# Altium schematic sheet logika_sch_ideowy.SchDoc
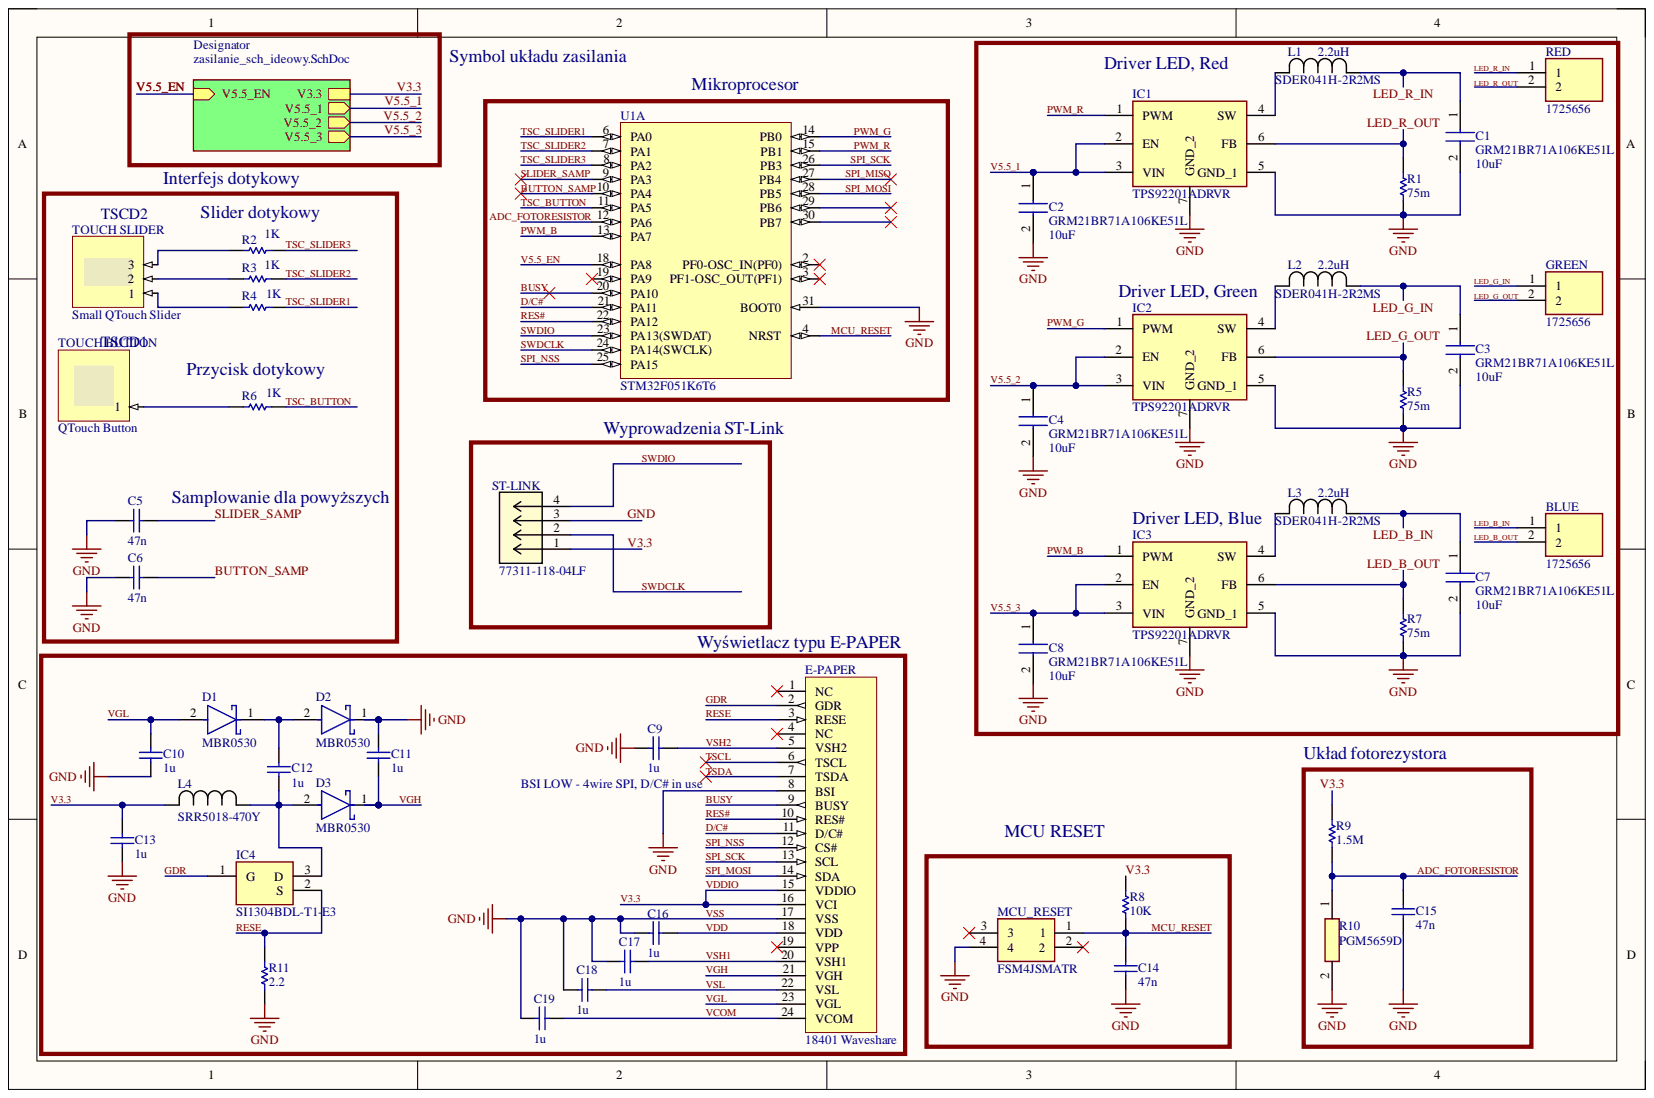
[diagram p0: logika_sch_ideowy.SchDoc]

## Parts
BLUE  1725656  [1725656]
C1  GRM21BR71A106KE51L  Capacitance: 10uF  [CAPC2012X145N]
C2  GRM21BR71A106KE51L  Capacitance: 10uF  [CAPC2012X145N]
C3  GRM21BR71A106KE51L  Capacitance: 10uF  [CAPC2012X145N]
C4  GRM21BR71A106KE51L  Capacitance: 10uF  [CAPC2012X145N]
C5  value 47n  [Capacitor_0805]  (hidden comment: Capacitor)
C6  value 47n  [Capacitor_0805]  (hidden comment: Capacitor)
C7  GRM21BR71A106KE51L  Capacitance: 10uF  [CAPC2012X145N]
C8  GRM21BR71A106KE51L  Capacitance: 10uF  [CAPC2012X145N]
C9  value 1u  [Capacitor_0805]  (hidden comment: Capacitor)
C10  value 1u  [Capacitor_0805]  (hidden comment: Capacitor)
C11  value 1u  [Capacitor_0805]  (hidden comment: Capacitor)
C12  value 1u  [Capacitor_0805]  (hidden comment: Capacitor)
C13  value 1u  [Capacitor_0805]  (hidden comment: Capacitor)
C14  value 47n  [Capacitor_0805]  (hidden comment: Capacitor)
C15  value 47n  [Capacitor_0805]  (hidden comment: Capacitor)
C16  value 1u  [Capacitor_0805]  (hidden comment: Capacitor)
C17  value 1u  [Capacitor_0805]  (hidden comment: Capacitor)
C18  value 1u  [Capacitor_0805]  (hidden comment: Capacitor)
C19  value 1u  [Capacitor_0805]  (hidden comment: Capacitor)
D1  MBR0530  [FP-425-04-MFG]
D2  MBR0530  [FP-425-04-MFG]
D3  MBR0530  [FP-425-04-MFG]
E-PAPER  18401 Waveshare  MPN: 18401  [18401 Waveshare]
GREEN  1725656  [1725656]
IC1  TPS92201ADRVR  [SON65P200X200X80-7N]
IC2  TPS92201ADRVR  [SON65P200X200X80-7N]
IC3  TPS92201ADRVR  [SON65P200X200X80-7N]
IC4  SI1304BDL-T1-E3  [SOT65P210X110-3N]
L1  SDER041H-2R2MS  value 2.2uH  [IND_SDER041H_CYN]
L2  SDER041H-2R2MS  value 2.2uH  [IND_SDER041H_CYN]
L3  SDER041H-2R2MS  value 2.2uH  [IND_SDER041H_CYN]
L4  SRR5018-470Y  [IND_BOURNS_SRR5018]
MCU_RESET  FSM4JSMATR  [FSM4JSMATR]
R1  value 75m  [Resistor_0805]  (hidden comment: Resistor)
R2  value 1K  [Resistor_0805]  (hidden comment: Resistor)
R3  value 1K  [Resistor_0805]  (hidden comment: Resistor)
R4  value 1K  [Resistor_0805]  (hidden comment: Resistor)
R5  value 75m  [Resistor_0805]  (hidden comment: Resistor)
R6  value 1K  [Resistor_0805]  (hidden comment: Resistor)
R7  value 75m  [Resistor_0805]  (hidden comment: Resistor)
R8  value 10K  [Resistor_0805]  (hidden comment: Resistor)
R9  value 1.5M  [Resistor_0805]  (hidden comment: Resistor)
R10  PGM5659D  [PGM5659D]
R11  value 2.2  [Resistor_0805]  (hidden comment: Resistor)
RED  1725656  [1725656]
ST-LINK  77311-118-04LF  [77311-118-04LF_AMP]
TOUCH_BUTTON  QTouch Button  (no BOM)
TOUCH_SLIDER  Small QTouch Slider  (no BOM)
U1  STM32F051K6T6  [STM-LQFP32_L]  (part A)

## Nets
ADC_FOTORESISTOR: C15.2 R9.1 R10.1 U1.12(PA6)
BUSY: E-PAPER.9(BUSY) U1.20(PA10)
BUTTON_SAMP: C6.2 U1.10(PA4)
D/C#: E-PAPER.11(D/C#) U1.21(PA11)
GDR: E-PAPER.2(GDR) IC4.1
GND: C1.2 C2.2 C3.2 C4.2 C5.1 C6.1 C7.2 C8.2 C9.1 C10.1 C11.2 C13.1 C14.1 C15.1 C16.1 C17.1 C18.1 C19.1 D2.1 E-PAPER.8(BSI) E-PAPER.17(VSS) IC1.5(GND_1) IC1.7(GND_2) IC2.5(GND_1) IC2.7(GND_2) IC3.5(GND_1) IC3.7(GND_2) MCU_RESET.4 R1.1 R5.1 R7.1 R10.2 R11.1 ST-LINK.3 U1.31(BOOT0)
LED_B_IN: BLUE.1 C7.1 L3.1
LED_B_OUT: BLUE.2 IC3.6(FB) R7.2
LED_G_IN: C3.1 GREEN.1 L2.1
LED_G_OUT: GREEN.2 IC2.6(FB) R5.2
LED_R_IN: C1.1 L1.1 RED.1
LED_R_OUT: IC1.6(FB) R1.2 RED.2
MCU_RESET: C14.2 MCU_RESET.1 R8.1 U1.4(NRST)
PWM_B: IC3.1(PWM) U1.13(PA7)
PWM_G: IC2.1(PWM) U1.14(PB0)
PWM_R: IC1.1(PWM) U1.15(PB1)
RES#: E-PAPER.10(RES#) U1.22(PA12)
RESE: E-PAPER.3(RESE) IC4.2 R11.2
SLIDER_SAMP: C5.2 U1.9(PA3)
SPI_MISO: U1.27(PB4)
SPI_MOSI: E-PAPER.14(SDA) U1.28(PB5)
SPI_NSS: E-PAPER.12(CS#) U1.25(PA15)
SPI_SCK: E-PAPER.13(SCL) U1.26(PB3)
SWDCLK: ST-LINK.2 U1.24(PA14(SWCLK))
SWDIO: ST-LINK.4 U1.23(PA13(SWDAT))
TSC_BUTTON: R6.2 U1.11(PA5)
TSC_SLIDER1: R4.2 U1.6(PA0)
TSC_SLIDER2: R3.2 U1.7(PA1)
TSC_SLIDER3: R2.2 U1.8(PA2)
TSCL: E-PAPER.6(TSCL)
TSDA: E-PAPER.7(TSDA)
V3.3: C13.2 E-PAPER.15(VDDIO) E-PAPER.16(VCI) L4.2 R8.2 R9.2 ST-LINK.1
V5.5_1: C2.1 IC1.2(EN) IC1.3(VIN)
V5.5_2: C4.1 IC2.2(EN) IC2.3(VIN)
V5.5_3: C8.1 IC3.2(EN) IC3.3(VIN)
V5.5_EN: U1.18(PA8)
VCOM: C19.2 E-PAPER.24(VCOM)
VDD: C16.2 E-PAPER.18(VDD)
VGH: C11.1 D3.1 E-PAPER.21(VGH)
VGL: C10.2 D1.2 E-PAPER.23(VGL)
VSH1: C17.2 E-PAPER.20(VSH1)
VSH2: C9.2 E-PAPER.5(VSH2)
VSL: C18.2 E-PAPER.22(VSL)
NetC12_1: C12.1 D3.2 IC4.3 L4.1
NetC12_2: C12.2 D1.1 D2.2
NetIC1_4: IC1.4(SW) L1.2
NetIC2_4: IC2.4(SW) L2.2
NetIC3_4: IC3.4(SW) L3.2
NetR2_1: R2.1 TOUCH_SLIDER.3
NetR3_1: R3.1 TOUCH_SLIDER.2
NetR4_1: R4.1 TOUCH_SLIDER.1
NetR6_1: R6.1 TOUCH_BUTTON.1

Not connected: E-PAPER 1(NC) 4(NC) 19(VPP); MCU_RESET 2 3; U1 2(PF0-OSC_IN(PF0)) 3(PF1-OSC_OUT(PF1)) 19(PA9) 29(PB6) 30(PB7)

## Library data of the parts
BLUE GREEN RED = 1725656: 2-pin screw terminal block Connector; Manufacturer_Name: Phoenix Contact; Arrow Part Number: 1725656; Arrow Price/Stock: https://www.arrow.com/en/products/1725656/phoenix-contact?utm_currency=USD&region=nac; Component Kind: Standard; Datasheet Link: https://www.phoenixcontact.com/online/portal/us/?uri=pxc-oc-itemdetail:pid=1725656&library=usen&pcck=P-11-01-05&tab=1&selectedCategory=ALL; Height: 8.65mm; Library Name: Schlib1.SchLib; Manufacturer_Part_Number: 1725656; Mouser Part Number: 651-1725656; Mouser Price/Stock: https://www.mouser.co.uk/ProductDetail/Phoenix-Contact/1725656?qs=Ul7CXFMnlWWQeccayYbRmw%3D%3D
C1-C4 C7 C8 = GRM21BR71A106KE51L: Capacitor, LED DRIVER; Manufacturer_Name: Murata Electronics; Arrow Part Number: GRM21BR71A106KE51L; Arrow Price/Stock: https://www.arrow.com/en/products/grm21br71a106ke51l/murata-manufacturing; Component Kind: Standard; Datasheet Link: https://search.murata.co.jp/Ceramy/image/img/A01X/G101/ENG/GRM21BR71A106KE51-01.pdf; Height: 1.45mm; Library Name: Schlib1.SchLib; Manufacturer_Part_Number: GRM21BR71A106KE51L; Mouser Part Number: 81-GRM21BR71A106KE51; Mouser Price/Stock: https://www.mouser.co.uk/ProductDetail/Murata-Electronics/GRM21BR71A106KE51L?qs=kHCDrmfBqSAdNFLacabF8w%3D%3D
C5 C6 C14 C15 = Capacitor: SimulationGenericComponent: True; Component Kind: Standard; Description: Capacitor; Library Name: Simulation Generic Components.IntLib; Simulation: C_Capacitor_Default
C9-C12 C17-C19 = Capacitor: Capacitor X5R/X7R, 25V; SimulationGenericComponent: True; Component Kind: Standard; Library Name: Simulation Generic Components.IntLib; Simulation: C_Capacitor_Default
C13 C16 = Capacitor: Capacitor X5R/X7R, 6V/25V; SimulationGenericComponent: True; Component Kind: Standard; Library Name: Simulation Generic Components.IntLib; Simulation: C_Capacitor_Default
D1-D3 = 1d6ec82d83fb6aee721767c19dac4b9: DIODE SCHOTTKY 30V 500MA SOD123; Element Configuration: Single; Forward Voltage: 430mV; Max Forward Surge Current (Ifsm): 5.5A; Max Reverse Leakage Current: 130uA; Polarity: Standard; Average Rectified Current: 500mA; Component Kind: Standard; Contact Plating: Tin; Current Rating: 500mA; Forward Current: 500mA; Height: 1.03mm; Lead Free: Lead Free; Length: 2.7mm; Library Name: Schlib1.SchLib; Max Operating Temperature: 150°C; Max Repetitive Reverse Voltage (Vrrm): 30V; Max Reverse Voltage (DC): 30V; Max Surge Current: 5.5A; Min Operating Temperature: -65°C; Mount: Surface Mount; Number of Pins: 2; Output Current: 500mA; Package Quantity: 1; Packaging: Tape and Reel; Peak Non-Repetitive Surge Current: 5.5A; Peak Reverse Current: 130uA; Radiation Hardening: No; REACH SVHC: No SVHC; Voltage Rating (DC): 30V; Weight: 0.03g; Width: 1.6mm
E-PAPER = 18401 Waveshare: E-PAPER display; Component Kind: Standard; Datasheet: https://www.tme.eu/Document/e202719f7ea7de7a184ea88bdc3f3b85/WSH-18321.pdf; Library Name: Schlib1.SchLib; TME: https://www.tme.eu/pl/details/wsh-18401/e-papier/waveshare/18401/; Wiki: https://www.waveshare.com/wiki/2.66inch_e-Paper_Module
IC1-IC3 = TPS92201ADRVR: Integrated Circuit; Manufacturer_Name: Texas Instruments; Component Kind: Standard; Datasheet Link: https://www.ti.com/lit/ds/symlink/tps92201a.pdf?ts=1701335044681; Height: 0.8mm; Library Name: Schlib1.SchLib; Manufacturer_Part_Number: TPS92201ADRVR; Mouser Part Number: 595-TPS92201ADRVR; Mouser Price/Stock: https://www.mouser.co.uk/ProductDetail/Texas-Instruments/TPS92201ADRVR?qs=Z%252BL2brAPG1KZs1rryKr0Dw%3D%3D
IC4 = SI1304BDL-T1-E3: Integrated Circuit; Manufacturer_Name: Vishay; Component Kind: Standard; Datasheet Link: http://www.vishay.com/docs/73480/si1304bd.pdf; Height: 1.1mm; Library Name: Schlib1.SchLib; Manufacturer_Part_Number: SI1304BDL-T1-E3; Mouser Part Number: 781-SI1304BDL-E3; Mouser Price/Stock: https://www.mouser.co.uk/ProductDetail/Vishay-Siliconix/SI1304BDL-T1-E3?qs=jcx%252B0HVgj2YToZIV6Xy8pQ%3D%3D
L1-L3 = SDER041H-2R2MS: Inductor, LED Driver; Mfr_Name: Cyntec; Component Kind: Standard; Library Name: Schlib1.SchLib
L4 = SRR5018-470Y: Inductor; Mfr_Name: Bourns Electronics; Component Kind: Standard; Library Name: Schlib1.SchLib
MCU_RESET = FSM4JSMATR: Switch; Manufacturer_Name: TE Connectivity; Arrow Part Number: FSM4JSMATR; Arrow Price/Stock: https://www.arrow.com/en/products/fsm4jsmatr/te-connectivity?utm_currency=USD&region=nac; Component Kind: Standard; Datasheet Link: https://www.te.com/commerce/DocumentDelivery/DDEController?Action=srchrtrv&DocNm=1654270-2&DocType=Catalog%20Section&DocLang=English&PartCntxt=4-1437565-2&DocFormat=pdf; Library Name: Schlib1.SchLib; Manufacturer_Part_Number: FSM4JSMATR; Mouser Part Number: 506-FSM4JSMATR; Mouser Price/Stock: https://www.mouser.co.uk/ProductDetail/TE-Connectivity-Alcoswitch/FSM4JSMATR?qs=wvf%252BmaPDr9OW%2FGIpfy5A8Q%3D%3D
R1 R5 R7 = Resistor: Rsense; SimulationGenericComponent: True; TC1: 0; Component Kind: Standard; Library Name: Simulation Generic Components.IntLib; Simulation: R_Resistor_Default; TC2: 0
R2-R4 R6 R8 R9 = Resistor: SimulationGenericComponent: True; TC1: 0; Component Kind: Standard; Description: Resistor; Library Name: Simulation Generic Components.IntLib; Simulation: R_Resistor_Default; TC2: 0
R10 = PGM5659D: Resistor; Manufacturer_Name: TOKEN ELECTRONICS INDUSTRY CO LTD; Component Kind: Standard; Datasheet Link: http://www.token.com.tw/token-old/pdf/resistor/cds-resistor-pgm.pdf; Height: 2.4mm; Library Name: Schlib1.SchLib; Manufacturer_Part_Number: PGM5659D
R11 = Resistor: Resistor 0805 1%; SimulationGenericComponent: True; TC1: 0; Component Kind: Standard; Library Name: Simulation Generic Components.IntLib; Simulation: R_Resistor_Default; TC2: 0
ST-LINK = 77311-118-04LF: 4-pin male goldpin header; Mfr_Name: Amphenol ICC; Component Kind: Standard; Library Name: Schlib1.SchLib; RefDes: RefDes; Type: Type
TOUCH_BUTTON = QTouchButton: ConfigurationParameters: {}__sensorid[1]{}width[15]{}height[15]{}; ConfiguratorName: ATMEL_TOUCH; Description: QTouch Button; Library Name: Atmel QTouch.SchLib
TOUCH_SLIDER = SmallQTouchSlider: ConfigurationParameters: {}__sensorid[3]{}width[25]{}height[12]{}gapthickness[0.3]{}; ConfiguratorName: ATMEL_TOUCH; Description: Small QTouch Slider; Library Name: Atmel QTouch.SchLib
U1 = CMP-0304-00023-2: ARM Cortex-M0 32-bit MCU, 32 KB Flash, 4 KB Internal RAM, 25 I/Os, 32-pin LQFP, -40 to 85 degC, Tray; FLASH Size (Prog) (kB): 32; I/Os (High Current): 25; Internal RAM Size (kB): 4; Operating Frequency(F) (Processor speed) (MHz): 48; Supply Voltage(Vcc) min (V): 2; Component Kind: Standard; ComponentLink1Description: Manufacturer URL; ComponentLink1URL: http://www.st.com/web/en/home.html; ComponentLink2Description: Datasheet; ComponentLink2URL: http://www.st.com/st-web-ui/static/active/en/resource/technical/document/datasheet/DM00039193.pdf; ComponentLink3Description: Package Specification; ComponentLink3URL: http://www.st.com/st-web-ui/static/active/en/resource/technical/document/datasheet/DM00088500.pdf; Core: ARM Cortex-M0; DatasheetVersion: Rev. 3; Library Name: Altium Content Vault; Mounting Technology: Surface Mount; PackageDescription: 32-Pin Low Profile Quad Flat Package, 7 x 7 mm Body, 0.8 mm Pitch; PackageReference: LQFP32; PackageVersion: Rev. 1, 07/2013; Packing: Tray; PartNumber: STM32F051K6T6
